# Altium schematic sheet DEVICE1.SchDoc
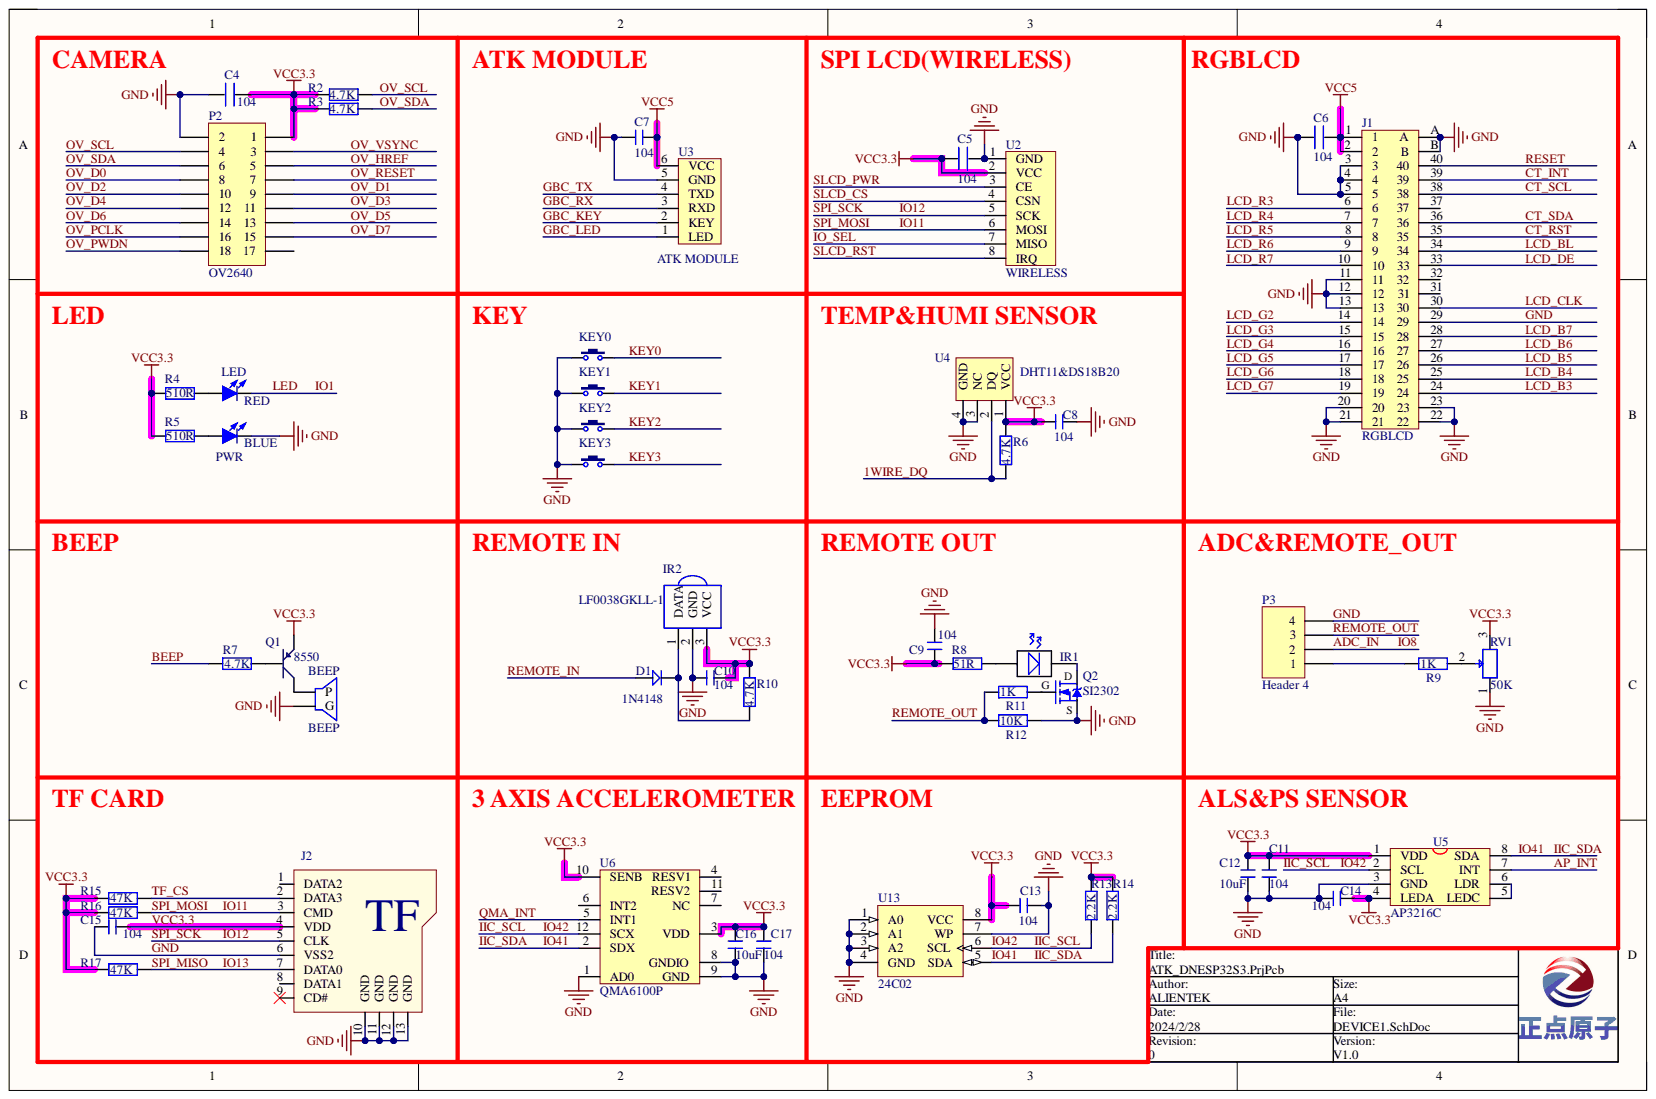
[diagram p0: DEVICE1.SchDoc]

## Parts
BEEP  BEEP  [BELL-5]
C4  104  [C 0402]
C5  104  [C 0402]
C6  104  [C 0402]
C7  104  [C 0402]
C8  104  [C 0402]
C9  104  [C 0402]
C10  104  [C 0402]
C11  104  [C 0402]
C12  10uF  [C 0603]
C13  104  [C 0402]
C14  104  [C 0402]
C15  104  [C 0402]
C16  10uF  [C 0603]
C17  104  [C 0402]
D1  1N4148  [DIODE_SOP]
IR1  IR-LED  [IR-LED]  (value not drawn)
IR2  LF0038GKLL-1  [LF0038GKLL-1]
J1  RGBLCD  [FPC-TOP40]
J2  SD-MICRO-TF  [SD-MICRO-TF]  (value not drawn)
KEY0  KEY  [KEY 3.2*4.2*2.5 4P SMD]
KEY1  KEY  [KEY 3.2*4.2*2.5 4P SMD]
KEY2  KEY  [KEY 3.2*4.2*2.5 4P SMD]
KEY3  KEY  [KEY 3.2*4.2*2.5 4P SMD]
LED  RED  [LED 0603 Red]
P2  OV2640  [Socket 2.54 2X9P]
P3  Header 4  [HDR1X4]
PWR  BLUE  [LED 0603 Blue]
Q1  8550  [SOT-23R]
Q2  SI2302  [SOT23-3N]
R2  4.7K  [R 0402]
R3  4.7K  [R 0402]
R4  510R  [R 0402]
R5  510R  [R 0402]
R6  4.7K  [R 0402]
R7  4.7K  [R 0402]
R8  51R  [R 0402]
R9  1K  [R 0402]
R10  4.7K  [R 0402]
R11  1K  [R 0402]
R12  10K  [R 0402]
R13  2.2K  [R 0402]
R14  2.2K  [R 0402]
R15  47K  [R 0402]
R16  47K  [R 0402]
R17  47K  [R 0402]
RV1  50K  [RV]
U2  WIRELESS  [SPI_LCD]
U3  ATK MODULE  [Socket 2.54 1X6P]
U4  DHT11&DS18B20  [DHT11]
U5  AP3216C  [QFN8]
U6  QMA6100P  [QMA6100P]
U13  24C02  [SOP8_L]

## Nets
VCC5: C6.2 C7.1 J1.1 J1.2 U3.6(VCC)
1WIRE_DQ: R6.1 U4.2(DQ)
ADC_IN: P3.2
AP_INT: U5.7(INT)
BEEP: R7.1
CT_INT: J1.39
CT_RST: J1.35
CT_SCL: J1.38
CT_SDA: J1.36
GBC_KEY: U3.2(KEY)
GBC_LED: U3.1(LED)
GBC_RX: U3.3(RXD)
GBC_TX: U3.4(TXD)
GND: BEEP.2 C4.2 C5.1 C6.1 C7.2 C8.1 C9.1 C10.2 C11.2 C12.2 C13.1 C14.1 C15.2 C16.2 C17.2 IR2.2 J1.3 J1.4 J1.5 J1.11 J1.12 J1.13 J1.20 J1.21 J1.22 J1.23 J1.29 J1.A J1.B J2.6(VSS2) J2.10(GND) J2.11(GND) J2.12(GND) J2.13(GND) KEY0.1 KEY1.1 KEY2.1 KEY3.1 P2.2 P3.4 PWR.2 Q2.2 R12.2 RV1.1 U2.1(GND) U3.5(GND) U4.3(NC) U4.4(GND) U5.3(GND) U6.1(AD0) U6.8(GNDIO) U6.9(GND) U13.1(A0) U13.2(A1) U13.3(A2) U13.4(GND) U13.7(WP)
IIC_SCL: R13.1 U5.2(SCL) U6.12(SCX) U13.6(SCL)
IIC_SDA: R14.1 U5.8(SDA) U6.2(SDX) U13.5(SDA)
IO1: LED.2
IO11: J2.3(CMD) R16.2 U2.6(MOSI)
IO12: J2.5(CLK) U2.5(SCK)
IO13: J2.7(DATA0) R17.2
IO_SEL: U2.7(MISO)
KEY0: KEY0.2
KEY1: KEY1.2
KEY2: KEY2.2
KEY3: KEY3.2
LCD_B3: J1.24
LCD_B4: J1.25
LCD_B5: J1.26
LCD_B6: J1.27
LCD_B7: J1.28
LCD_BL: J1.34
LCD_CLK: J1.30
LCD_DE: J1.33
LCD_G2: J1.14
LCD_G3: J1.15
LCD_G4: J1.16
LCD_G5: J1.17
LCD_G6: J1.18
LCD_G7: J1.19
LCD_R3: J1.6
LCD_R4: J1.7
LCD_R5: J1.8
LCD_R6: J1.9
LCD_R7: J1.10
OV_D0: P2.8
OV_D1: P2.9
OV_D2: P2.10
OV_D3: P2.11
OV_D4: P2.12
OV_D5: P2.13
OV_D6: P2.14
OV_D7: P2.15
OV_HREF: P2.5
OV_PCLK: P2.16
OV_PWDN: P2.18
OV_RESET: P2.7
OV_SCL: P2.4 R2.2
OV_SDA: P2.6 R3.2
OV_VSYNC: P2.3
QMA_INT: U6.5(INT1)
REMOTE_IN: D1.1
REMOTE_OUT: P3.3 R11.1 R12.1
RESET: J1.40
SLCD_CS: U2.4(CSN)
SLCD_PWR: U2.3(CE)
SLCD_RST: U2.8(IRQ)
TF_CS: J2.2(DATA3) R15.2
VCC3.3: C4.1 C5.2 C8.2 C9.2 C10.1 C11.1 C12.1 C13.2 C14.2 C15.1 C16.1 C17.1 IR2.3 J2.4(VDD) P2.1 Q1.1 R2.1 R3.1 R4.1 R5.1 R6.2 R8.2 R10.2 R13.2 R14.2 R15.1 R16.1 R17.1 RV1.3 U2.2(VCC) U4.1(VCC) U5.1(VDD) U5.4(LEDA) U6.3(VDD) U6.10(SENB) U13.8(VCC)
NetBEEP_1: BEEP.1 Q1.3
NetD1_2: D1.2 IR2.1 R10.1
NetIR1_1: IR1.1 R8.1
NetIR1_2: IR1.2 Q2.3
NetLED_1: LED.1 R4.2
NetP3_1: P3.1 R9.1
NetPWR_1: PWR.1 R5.2
NetQ1_2: Q1.2 R7.2
NetQ2_1: Q2.1 R11.2
NetR9_2: R9.2 RV1.2
NetU5_5: U5.5(LEDC) U5.6(LDR)

Not connected: J1 31 32 37; J2 1(DATA2) 8(DATA1) 9(CD#); P2 17; U6 4(RESV1) 6(INT2) 7(NC) 11(RESV2)

## Library data of the parts
C4-C6 = C: Component Kind: Standard
  C4 C5: Library Name: MYCOMLIB.IntLib
  C6: Library Name: ALIENTEK_COMPONENT.IntLib
C7 C8 C10 = C: 无极性贴片电容; 版权归属: 源创客; BOM名称: 无极性贴片电容; Component Kind: Standard; Library Name: 电阻-电容-电感.SchLib; 学习资源: www.ooocke.com
C9 C11-C17 = C: 无极性电容; BOM名称: 无极性贴片电容; 版权归属: 正点原子; Component Kind: Standard; Library Name: 电容.SchLib; 学习资源: www.openedv.com
D1 = D: Component Kind: Standard; Description: 1N4148; Library Name: MYCOMLIB.IntLib
IR1 = IR-LED: 1206红外发射管（侧）; Component Kind: Standard; Library Name: 刘军临时封装库_SCH库.SchLib
IR2 = LF0038GKLL-1: 红外接收管SMD; Component Kind: Standard; Library Name: ALIENTEK_COMPONENT.IntLib
J1 = FPC-40: FPC-40P-0.5mm; Component Kind: Standard; Library Name: ALIENTEK_COMPONENT.IntLib
J2 = SD-MICRO-TF: SD,MICRO,TF; Component Kind: Standard; Library Name: 正点原子封装库V1.0.IntLib
KEY0-KEY3 = KEY: 按键; BOM名称: 3x6轻触开关; Parameter: 按键/开关; 版权归属: 正点原子; Component Kind: Standard; Library Name: 按键-开关.SchLib; 学习资源: www.openedv.com
LED PWR = LED: BOM名称: 插件LED; Parameter: 发光二极管; 版权归属: 正点原子; Component Kind: Standard; Description: LED; Library Name: 二极管_LED.SchLib; 学习资源: www.openedv.com
P2 = Header 9X2: Header, 9-Pin, Dual row; Component Kind: Standard; LatestRevisionDate: 17-Jul-2002; LatestRevisionNote: Re-released for DXP Platform.; Library Name: Miscellaneous Connectors.IntLib; Publisher: Altium Limited
P3 = Header 4: Header, 4-Pin; Component Kind: Standard; LatestRevisionDate: 17-Jul-2002; LatestRevisionNote: Re-released for DXP Platform.; Library Name: Miscellaneous Connectors.IntLib; Signal Integrity: Connector; Publisher: Altium Limited
Q1 = PNP: 8550/BCW68; Component Kind: Standard; Library Name: ALIENTEK_COMPONENT.IntLib
Q2 = SI2302: NMOS 20V-+3.0A 55mΩ; BOM名称: N沟道场效应管; Parameter: MOS管; 版权归属: 正点原子; Component Kind: Standard; Library Name: 正点原子封装库V1.0.IntLib; 学习资源: www.openedv.com
R2-R5 = R: 贴片电阻; 版权归属: 源创客; BOM名称: 贴片电阻; Component Kind: Standard; Library Name: 3.1   - 电阻-电容-电感.SchLib; 学习资源: www.ooocke.com
R6-R17 = R: 电阻; BOM名称: 贴片电阻; 版权归属: 正点原子; Component Kind: Standard; Library Name: 电阻.SchLib; Parameter: 电阻; 学习资源: www.openedv.com
RV1 = RV: Component Kind: Standard; Library Name: ALIENTEK_COMPONENT.IntLib
U2 = NRF24L01: Component Kind: Standard; Library Name: MYCOMLIB.IntLib
U3 = ATK-HC05: Component Kind: Standard; Description: ATK-HC05; Library Name: ALIENTEK_COMPONENT.IntLib
U4 = DHT11: 数字温湿度传感器; Component Kind: Standard; Library Name: ALIENTEK_COMPONENT.IntLib
U5 = AP3216C: ALS+PS Sensor; Component Kind: Standard; Library Name: ALIENTEK_COMPONENT.IntLib
U6 = QMA6100P: 姿态传感器-陀螺仪; Component Kind: Standard; Library Name: 刘军临时封装库_SCH库.SchLib
U13 = 24Cxx: 外置EEPROM; 版权归属: 源创客; BOM名称: 外置EEPROM; Component Kind: Standard; Library Name: 1.1   -IC芯片.SchLib; 学习资源: www.ooocke.com
BEEP = BEEP: Component Kind: Standard; Library Name: ALIENTEK_COMPONENT.IntLib
